# Altium schematic sheet P03_MPU2_Comm.SchDoc(P03_MPU2_Comm)
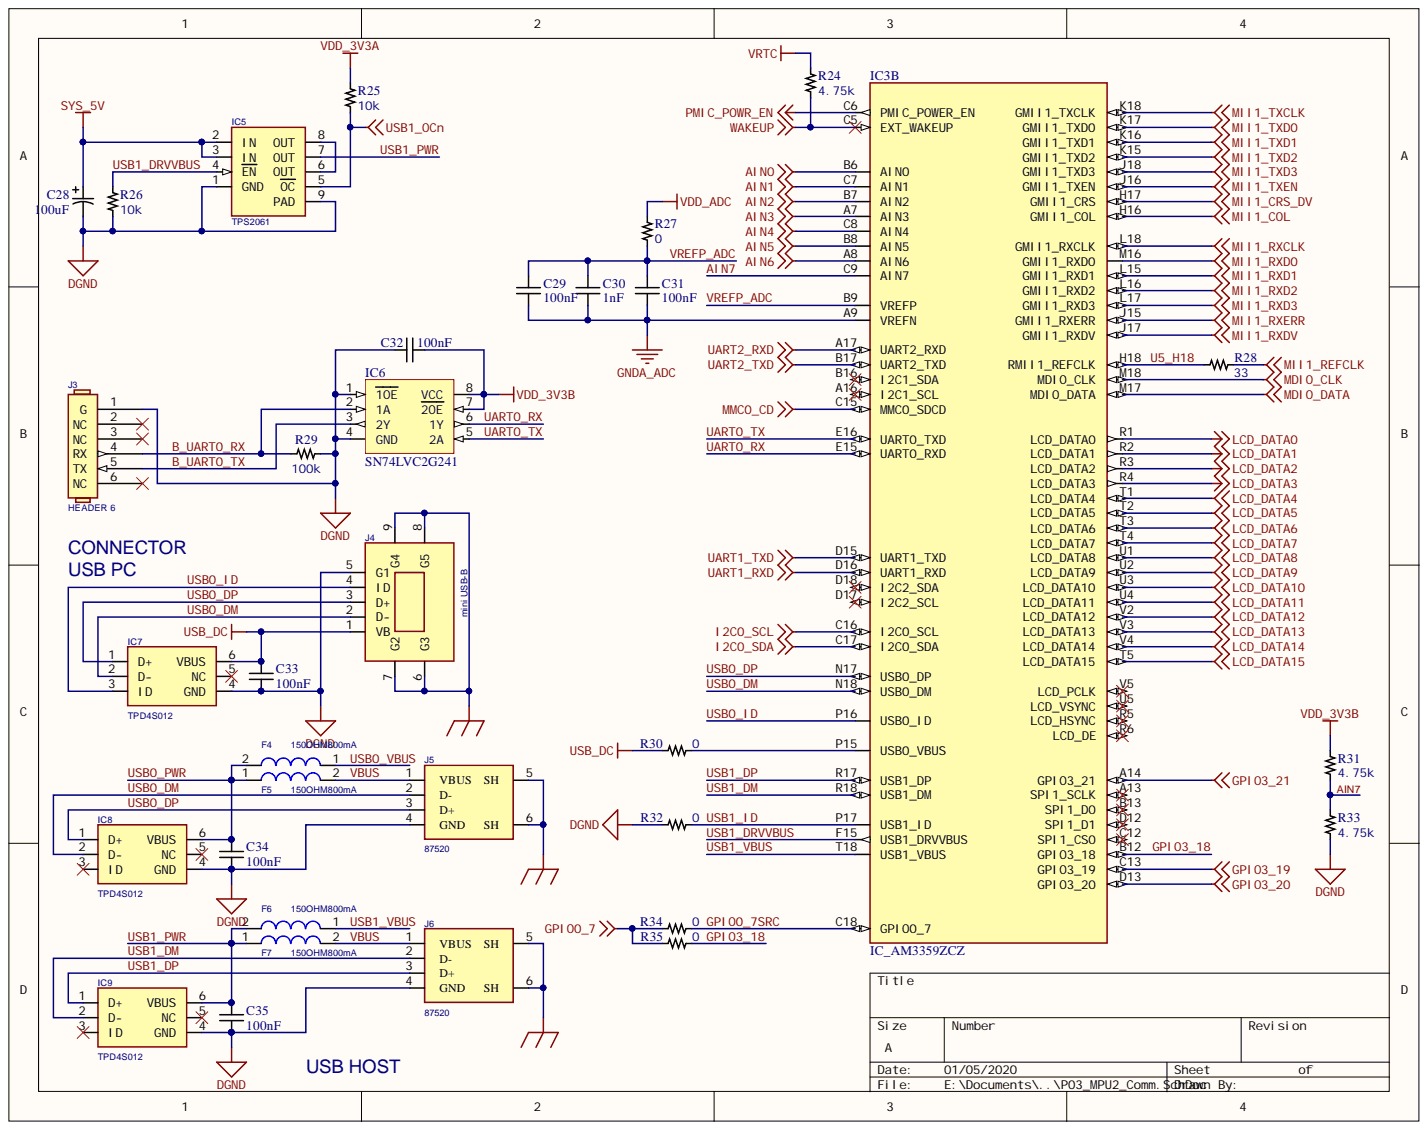
[diagram p0: P03_MPU2_Comm.SchDoc]

## Parts
C28  Capacitance: 100uF  [CAP6.6x6.6]  (hidden comment: EEE-0JA101SP)
C29  Capacitance: 100nF  [CAP0402]  (hidden comment: C0402C104K4RACTU)
C30  Capacitance: 1nF  [CAP0402]  (hidden comment: CC0402KRX7R9BB102)
C31  Capacitance: 100nF  [CAP0402]  (hidden comment: C0402C104K4RACTU)
C32  Capacitance: 100nF  [CAP0402]  (hidden comment: C0402C104K4RACTU)
C33  Capacitance: 100nF  [CAP0402]  (hidden comment: C0402C104K4RACTU)
C34  Capacitance: 100nF  [CAP0402]  (hidden comment: C0402C104K4RACTU)
C35  Capacitance: 100nF  [CAP0402]  (hidden comment: C0402C104K4RACTU)
F4  150OHM800mA  [FB0805]
F5  150OHM800mA  [FB0805]
F6  150OHM800mA  [FB0805]
F7  150OHM800mA  [FB0805]
IC3  IC_AM3359ZCZ  [BGA-324]  (part B)
IC5  TPS2061  [HVSSOP-8]
IC6  SN74LVC2G241  [VSSOP-8]
IC7  TPD4S012  [U_6_DRY]
IC8  TPD4S012  [U_6_DRY]
IC9  TPD4S012  [U_6_DRY]
J3  HEADER 6  [SIP6]
J4  mini USB-B  [USB5MINI_4SHIELDED_SMD-5]
J5  87520  [USB_A]
J6  87520  [USB_A]
R24  Resistance: 4.75k  [RES0402]  (hidden comment: RC0402FR-074K75L)
R25  Resistance: 10k  [RES0402]  (hidden comment: RC0402FR-0710KL)
R26  Resistance: 10k  [RES0402]  (hidden comment: RC0402FR-0710KL)
R27  RC0402JR-070RL  [RES0402]  (value not drawn)
R28  RC0402FR-0733RL  [RES0402]  (value not drawn)
R29  Resistance: 100k  [RES0402]  (hidden comment: RC0402FR-07100KL)
R30  RC0402JR-070RL  [RES0402]  (value not drawn)
R31  Resistance: 4.75k  [RES0402]  (hidden comment: RC0402FR-074K75L)
R32  RC0402JR-070RL  [RES0402]  (value not drawn)
R33  Resistance: 4.75k  [RES0402]  (hidden comment: RC0402FR-074K75L)
R34  RC0402JR-070RL  [RES0402]  (value not drawn)
R35  RC0402JR-070RL  [RES0402]  (value not drawn)

## Nets
AIN0: IC3.B6(AIN0)
AIN1: IC3.C7(AIN1)
AIN2: IC3.B7(AIN2)
AIN3: IC3.A7(AIN3)
AIN4: IC3.C8(AIN4)
AIN5: IC3.B8(AIN5)
AIN6: IC3.A8(AIN6)
DGND: C28.2 C32.1 C33.2 C34.2 C35.2 IC5.1(GND) IC5.9(PAD) IC6.1(1OE) IC6.4(GND) IC7.4(GND) IC8.4(GND) IC9.4(GND) J3.1(G) J4.5(G1) J5.4(GND) J6.4(GND) R26.2 R29.1 R32.1 R33.1
GND_EARTH: J4.6(G3) J4.7(G2) J4.8(G5) J4.9(G4) J5.5(SH) J5.6(SH) J6.5(SH) J6.6(SH)
GNDA_ADC: C29.2 C30.2 C31.2 IC3.A9(VREFN)
GPIO0_7: R34.2 R35.1
GPIO3_19: IC3.C13(GPIO3_19)
GPIO3_20: IC3.D13(GPIO3_20)
GPIO3_21: IC3.A14(GPIO3_21)
I2C0_SCL: IC3.C16(I2C0_SCL)
I2C0_SDA: IC3.C17(I2C0_SDA)
LCD_DATA0: IC3.R1(LCD_DATA0)
LCD_DATA1: IC3.R2(LCD_DATA1)
LCD_DATA2: IC3.R3(LCD_DATA2)
LCD_DATA3: IC3.R4(LCD_DATA3)
LCD_DATA4: IC3.T1(LCD_DATA4)
LCD_DATA5: IC3.T2(LCD_DATA5)
LCD_DATA6: IC3.T3(LCD_DATA6)
LCD_DATA7: IC3.T4(LCD_DATA7)
LCD_DATA8: IC3.U1(LCD_DATA8)
LCD_DATA9: IC3.U2(LCD_DATA9)
LCD_DATA10: IC3.U3(LCD_DATA10)
LCD_DATA11: IC3.U4(LCD_DATA11)
LCD_DATA12: IC3.V2(LCD_DATA12)
LCD_DATA13: IC3.V3(LCD_DATA13)
LCD_DATA14: IC3.V4(LCD_DATA14)
LCD_DATA15: IC3.T5(LCD_DATA15)
MDIO_CLK: IC3.M18(MDIO_CLK)
MDIO_DATA: IC3.M17(MDIO_DATA)
MII1_COL: IC3.H16(GMII1_COL)
MII1_CRS_DV: IC3.H17(GMII1_CRS)
MII1_REFCLK: R28.2
MII1_RXCLK: IC3.L18(GMII1_RXCLK)
MII1_RXD0: IC3.M16(GMII1_RXD0)
MII1_RXD1: IC3.L15(GMII1_RXD1)
MII1_RXD2: IC3.L16(GMII1_RXD2)
MII1_RXD3: IC3.L17(GMII1_RXD3)
MII1_RXDV: IC3.J17(GMII1_RXDV)
MII1_RXERR: IC3.J15(GMII1_RXERR)
MII1_TXCLK: IC3.K18(GMII1_TXCLK)
MII1_TXD0: IC3.K17(GMII1_TXD0)
MII1_TXD1: IC3.K16(GMII1_TXD1)
MII1_TXD2: IC3.K15(GMII1_TXD2)
MII1_TXD3: IC3.J18(GMII1_TXD3)
MII1_TXEN: IC3.J16(GMII1_TXEN)
MMC0_CD: IC3.C15(MMC0_SDCD)
PMIC_POWR_EN: IC3.C6(PMIC_POWER_EN)
SYS_5V: C28.1 IC5.2(IN) IC5.3(IN)
UART1_RXD: IC3.D16(UART1_RXD)
UART1_TXD: IC3.D15(UART1_TXD)
UART2_RXD: IC3.A17(UART2_RXD)
UART2_TXD: IC3.B17(UART2_TXD)
USB1_OCn: IC5.5(OC) R25.2
USB_DC: C33.1 IC7.6(VBUS) J4.1(VB) R30.1
VDD_3V3A: R25.1
VDD_3V3B: C32.2 IC6.7(2OE) IC6.8(VCC) R31.2
VDD_ADC: R27.2
VRTC: R24.2
WAKEUP: IC3.C5(EXT_WAKEUP) R24.1
AIN7: IC3.C9(AIN7) R31.1 R33.2
B_UART0_RX: IC6.2(1A) J3.4(RX) R29.2
B_UART0_TX: IC6.3(2Y) J3.5(TX)
GPIO0_7SRC: IC3.C18(GPIO0_7) R34.1
GPIO3_18: IC3.B12(GPIO3_18) R35.2
U5_H18: IC3.H18(RMII1_REFCLK) R28.1
UART0_RX: IC3.E15(UART0_RXD) IC6.6(1Y)
UART0_TX: IC3.E16(UART0_TXD) IC6.5(2A)
USB0_DM: IC3.N18(USB0_DM) IC7.2(D-) IC8.2(D-) J4.2(D-) J5.2(D-)
USB0_DP: IC3.N17(USB0_DP) IC7.1(D+) IC8.1(D+) J4.3(D+) J5.3(D+)
USB0_ID: IC3.P16(USB0_ID) IC7.3(ID) J4.4(ID)
USB0_PWR: C34.1 F4.2 F5.1 IC8.6(VBUS)
USB0_VBUS: F4.1
USB1_DM: IC3.R18(USB1_DM) IC9.2(D-) J6.2(D-)
USB1_DP: IC3.R17(USB1_DP) IC9.1(D+) J6.3(D+)
USB1_DRVVBUS: IC3.F15(USB1_DRVVBUS) IC5.4(EN) R26.1
USB1_ID: IC3.P17(USB1_ID) R32.2
USB1_PWR: C35.1 F6.2 F7.1 IC5.7(OUT) IC9.6(VBUS)
USB1_VBUS: F6.1 IC3.T18(USB1_VBUS)
VBUS: F5.2 F7.2 J5.1(VBUS) J6.1(VBUS)
VREFP_ADC: C29.1 C30.1 C31.1 IC3.B9(VREFP) R27.1
NetIC3_P15: IC3.P15(USB0_VBUS) R30.2
NetIC5_6: IC5.6(OUT) IC5.8(OUT)

Not connected: IC3 A13(SPI1_SCLK) A16(I2C1_SCL) B13(SPI1_D0) B16(I2C1_SDA) C12(SPI1_CS0) D12(SPI1_D1) D17(I2C2_SCL) D18(I2C2_SDA) R5(LCD_HSYNC) R6(LCD_DE) U5(LCD_VSYNC) V5(LCD_PCLK); IC7 5(NC); IC8 3(ID) 5(NC); IC9 3(ID) 5(NC); J3 2(NC) 3(NC) 6(NC)

## Library data of the parts
C28 = CAP_POL_100uF: POL CAP 100uF SMD; Manufacturer: Panasonic; Voltage Rating (DC): 6.3V; Tolerance: 20%; Ripple Current (AC): 71mA; Life (Hours): 2000Hour; Leakage Current: 3uA; Lead Length: 2.6mm; Dielectric Material: Aluminium; Width: 6.6mm; Voltage Rating: 6.3V; Voltage: 6.3V; Termination: SMD/SMT; Supplier Part Number 1: PCE3852CT-ND; Supplier 1: Digi-Key; Stock 1: 67789; RoHS: Yes; Ripple Current: 71mA; REACH SVHC: No SVHC; Radiation Hardening: No; Pricing 1: 1=0.35000, 10=0.24000, 100=0.14420, 500=0.10816 (USD); Packaging: Tape and Reel; Package Quantity: 1; Number of Pins: 2; Mount: Surface Mount,PCB; Min Operating Temperature: -40°C; Max Operating Temperature: 85°C; Manufacturer Part Number: EEE-0JA101SP; Length: 5.5mm; Lead Pitch: 2.2mm; Lead Free: Lead Free; Height - Seated (Max): 0.213inch; Height: 5.4mm; Features: General Purpose; Diameter: 6.3mm; Contact Plating: Tin; ComponentLink14URL: https://datasheet.ciiva.com/17353/aba0000ce2-17353295.pdf?src-supplier=Avnet+Europe; ComponentLink14Description: https://datasheet.ciiva.com/17353/aba0000ce2-17353295.pdf?src-supplier=Avnet+Europe; ComponentLink13URL: https://datasheet.ciiva.com/15165/getdatasheetpartid-73778-15165850.pdf?src-supplier=Verical; ComponentLink13Description: https://datasheet.ciiva.com/15165/getdatasheetpartid-73778-15165850.pdf?src-supplier=Verical; ComponentLink12URL: https://datasheet.ciiva.com/16539/aba0000ce2-16539929.pdf?src-supplier=Avnet+Asia; ComponentLink12Description: https://datasheet.ciiva.com/16539/aba0000ce2-16539929.pdf?src-supplier=Avnet+Asia; ComponentLink11URL: https://datasheet.ciiva.com/6134/aba0000ce2-6134361.pdf?src-supplier=Farnell; ComponentLink11Description: https://datasheet.ciiva.com/6134/aba0000ce2-6134361.pdf?src-supplier=Farnell; ComponentLink10URL: https://datasheet.ciiva.com/46376/aba0000ce2-46376375.pdf?src-supplier=Element14; ComponentLink10Description: https://datasheet.ciiva.com/46376/aba0000ce2-46376375.pdf?src-supplier=Element14; ComponentLink9URL: https://datasheet.ciiva.com/24070/aba0000ce2-40801-24070230.pdf?src-supplier=Mouser; ComponentLink9Description: https://datasheet.ciiva.com/24070/aba0000ce2-40801-24070230.pdf?src-supplier=Mouser; ComponentLink8URL: https://datasheet.ciiva.com/43485/aba0000ce2-43485605.pdf?src-supplier=Farnell; ComponentLink8Description: https://datasheet.ciiva.com/43485/aba0000ce2-43485605.pdf?src-supplier=Farnell; ComponentLink7URL: https://datasheet.ciiva.com/2983/aba0000ce2-2983902.pdf?src-supplier=Avnet; ComponentLink7Description: https://datasheet.ciiva.com/2983/aba0000ce2-2983902.pdf?src-supplier=Avnet; ComponentLink6URL: https://datasheet.ciiva.com/14595/70255710-14595757.pdf?src-supplier=Allied+Electronics; ComponentLink6Description: https://datasheet.ciiva.com/14595/70255710-14595757.pdf?src-supplier=Allied+Electronics; ComponentLink5URL: https://datasheet.ciiva.com/46387/aba0000ce2-46387964.pdf?src-supplier=Element14; ComponentLink5Description: https://datasheet.ciiva.com/46387/aba0000ce2-46387964.pdf?src-supplier=Element14; ComponentLink4URL: https://datasheet.ciiva.com/16397/aba0000ce2-16397066.pdf?src-supplier=Future+Electronics; ComponentLink4Description: https://datasheet.ciiva.com/16397/aba0000ce2-16397066.pdf?src-supplier=Future+Electronics; ComponentLink3URL: https://datasheet.ciiva.com/30226/11aba0000ce2-30226184.pdf?src-supplier=Onlinecomponents.com; ComponentLink3Description: https://datasheet.ciiva.com/30226/11aba0000ce2-30226184.pdf?src-supplier=Onlinecomponents.com; ComponentLink2URL: https://datasheet.ciiva.com/14610/70255710-14610022.pdf?src-supplier=Allied+Electronics; ComponentLink2Description: https://datasheet.ciiva.com/14610/70255710-14610022.pdf?src-supplier=Allied+Electronics; ComponentLink1URL: https://datasheet.ciiva.com/11779/0900766b8078636e-11779744.pdf?src-supplier=RSComponents; ComponentLink1Description: https://datasheet.ciiva.com/11779/0900766b8078636e-11779744.pdf?src-supplier=RSComponents; Component Kind: Standard; Case/Package: Radial; Library Name: Orient.SchLib
C29 C31-C35 = CAP_100nF: MLCC 100nF 0402 SMD; Manufacturer: KEMET; Voltage Rating (DC): 16V; Tolerance: 10%; Dissipation Factor: 3.5%; Dielectric: X7R; Width: 0.5mm; Voltage Rating: 16V; Termination: SMD/SMT; Supplier Part Number 1: 399-3521-1-ND; Supplier 1: Digi-Key; Stock 1: 6512243; RoHS: Yes; Radiation Hardening: No; Pricing 1: 1=0.10000, 10=0.04200, 100=0.01860, 500=0.01328, 1000=0.01044, 2500=0.00949, 5000=0.00873 (USD); Packaging: Tape and Reel; Package Quantity: 10000; Mount: Surface Mount; Min Operating Temperature: -55°C; Max Operating Temperature: 125°C; Manufacturer Part Number: C0402C104K4RACTU; Length: 1mm; Height: 0.5mm; Depth: 0.5mm; Contact Plating: Tin,Matte; ComponentLink2URL: https://datasheet.ciiva.com/18192/getdatasheetpartid-385384-18192412.pdf?src-supplier=Verical; ComponentLink2Description: Datasheet; Component Kind: Standard; Case Code (Metric): 1005; Case Code (Imperial): 0402; Case/Package: 0402; Library Name: Orient.SchLib
C30 = CAP_1nF: MLCC 1nF 0402 SMD; Manufacturer: Yageo; Voltage Rating: 50V; Tolerance: 10%; Insulation Resistance: 100GR; Dielectric Material: Ceramic; Width: 0.02inch; Thickness: 0.022inch; Supplier 1: Digi-Key; Stock 1: 3539064; RoHS: Yes; Pricing 1: 1=0.10000, 10=0.02100, 100=0.00920, 500=0.00654, 1000=0.00514, 2500=0.00468, 5000=0.00430 (USD); Packaging: Tape and Reel; Mount: Surface Mount; Min Operating Temperature: -55°C; Max Operating Temperature: 125°C; Manufacturer Part Number: CC0402KRX7R9BB102; Length: 0.039inch; Lead Free: Lead Free; Halogen Free: Halogen Free; ComponentLink9URL: https://datasheet.ciiva.com/22749/1489800-22749213.pdf?src-supplier=Element14; ComponentLink9Description: https://datasheet.ciiva.com/22749/1489800-22749213.pdf?src-supplier=Element14; ComponentLink8URL: https://datasheet.ciiva.com/7978/1489800-7978092.pdf?src-supplier=Element14; ComponentLink8Description: https://datasheet.ciiva.com/7978/1489800-7978092.pdf?src-supplier=Element14; ComponentLink7URL: https://datasheet.ciiva.com/15189/getdatasheetpartid-403474-15189131.pdf?src-supplier=Verical; ComponentLink7Description: https://datasheet.ciiva.com/15189/getdatasheetpartid-403474-15189131.pdf?src-supplier=Verical; ComponentLink6URL: https://datasheet.ciiva.com/16625/upy-gphc-x7r-6-3v-to-50v-8-16625512.pdf?src-supplier=Avnet+Europe; ComponentLink6Description: https://datasheet.ciiva.com/16625/upy-gphc-x7r-6-3v-to-50v-8-16625512.pdf?src-supplier=Avnet+Europe; ComponentLink5URL: https://datasheet.ciiva.com/13389/upy-gp-np0-16v-to-50v-8-13389974.pdf?src-supplier=Mouser; ComponentLink5Description: https://datasheet.ciiva.com/13389/upy-gp-np0-16v-to-50v-8-13389974.pdf?src-supplier=Mouser; ComponentLink4URL: https://datasheet.ciiva.com/143/mlccorderingcode-143958.pdf?src-supplier=Digi-Key; ComponentLink4Description: https://datasheet.ciiva.com/143/mlccorderingcode-143958.pdf?src-supplier=Digi-Key; ComponentLink3URL: https://datasheet.ciiva.com/143/mlccorderingcode-143957.pdf?src-supplier=Digi-Key; ComponentLink3Description: https://datasheet.ciiva.com/143/mlccorderingcode-143957.pdf?src-supplier=Digi-Key; ComponentLink2URL: https://datasheet.ciiva.com/143/mlccorderingcode-143956.pdf?src-supplier=Digi-Key; ComponentLink2Description: https://datasheet.ciiva.com/143/mlccorderingcode-143956.pdf?src-supplier=Digi-Key; ComponentLink1URL: https://datasheet.ciiva.com/11786/0900766b8079c870-11786157.pdf?src-supplier=RSComponents; ComponentLink1Description: https://datasheet.ciiva.com/11786/0900766b8079c870-11786157.pdf?src-supplier=RSComponents; Component Kind: Standard; Case Code (Metric): 1005; Case Code (Imperial): 0402; Case/Package: 0402; Library Name: Orient.SchLib
F4-F7 = FERR: FERRITE 800MA 150 OHM 0805 SMD; TIIntPartnumber: 1L0010; PartName: FerriteBead150ohm800mA; MfrNum: LI0805H151R-10; Mfr: Steward; Manufacturer: Laird-Signal Integrity Products; Vendor: Digikey; Impedance @ Frequency: 150 Ohm @ 100MHz; Filter Type: Differential Mode - Single; DC Resistance (DCR): 150 mOhm Max; Supplier Part Number 1: 240-2384-6-ND; Supplier 1: Digi-Key; Stock 1: 3694; Size / Dimension: 0.079" L x 0.049" W (2.00mm x 1.25mm); RoHS: RoHS Compliant; Pricing 1: 1=0.1, 10=0.07, 25=0.064, 50=0.0574, 100=0.0421, 250=0.03064, 500=0.02808, 1000=0.02424 (USD); Packaging: Digi-Reel®; Package / Case: 0805 (2012 Metric); Mounting Type: Surface Mount; Manufacturer Part Number: LI0805H151R-10; Height (Max): 0.043" (1.10mm); Current Rating: 800mA; ComponentLink1URL: http://www.lairdtech.com/WorkArea/linkit.aspx?LinkIdentifier=id&ItemID=4912; ComponentLink1Description: http://www.lairdtech.com/WorkArea/linkit.aspx?LinkIdentifier=id&ItemID=4912; Component Kind: Standard; Category: Filters; Library Name: Orient.SchLib
IC3 = IC_AM335X_ZCE_1: SubArctic Cortex A8 Processor 18x18; Manufacturer Part Number: XAM3359ZCZ; Manufacturer: Texas Instruments; Voltage: 1.06 V ~ 1.15 V; Processor Type: ARM Microprocessor; Supplier Part Number 1: 296-35073-ND; Supplier Device Package: 324-NFBGA(15x15); Supplier 1: Digi-Key; Stock 1: 16; Speed: -; RoHS: RoHS Compliant; Pricing 1: 1=33.5, 10=30.988, 100=26.465, 250=24.28752, 500=23.115, 1000=21.775, 2500=21.105, 5000=20.6025, 10000=20.1 (USD); Packaging: Tray; Package / Case: 324-LFBGA; Mounting Type: Surface Mount; Features: -; ComponentLink1URL: http://www.ti.com/lit/ds/symlink/am3354.pdf; ComponentLink1Description: http://www.ti.com/lit/ds/symlink/am3354.pdf; Component Kind: Standard; Category: Integrated Circuits (ICs); Library Name: Orient.SchLib
IC5 = TPS2061: IC 1.5A PWR DIST SWITCH 8-SOIC; Manufacturer Part Number: TPS2051BDGNR; Manufacturer: Texas Instruments; Voltage - Input: 2.7 V ~ 5.5 V; Rds (On): 135 mOhm; Number of Outputs: 1; Internal Switch(s): Yes; Current Limit: 1A; Type: High Side Switch; Supplier Part Number 1: 296-17313-6-ND; Supplier Device Package: 8-MSOP-PowerPad; Supplier 1: Digi-Key; Stock 1: 7065; RoHS: RoHS Compliant; Pricing 1: 1=1.82, 10=1.642, 25=1.4708, 100=1.3224, 250=1.1742, 500=1.026, 1000=0.8493 (USD); Packaging: Digi-Reel®; Package / Case: 8-TSSOP, 8-MSOP (0.118", 3.00mm Width) Exposed Pad; Operating Temperature: -40°C ~ 85°C; Mounting Type: Surface Mount; ComponentLink1URL: http://www.ti.com/lit/ds/symlink/tps2061.pdf; ComponentLink1Description: Datasheet; Component Kind: Standard; Category: Integrated Circuits (ICs); Library Name: Orient.SchLib
IC6 = SN74LVC2G241: IC BUFF/DVR TRI-ST DL 8VSSOP; Manufacturer Part Number: SN74LVC2G241DCUR; Manufacturer: Texas Instruments; Voltage - Supply: 1.65 V ~ 5.5 V; Number of Elements: 2; Number of Bits per Element: 1; Logic Type: Buffer/Line Driver, Non-Inverting; Current - Output High, Low: 32mA, 32mA; Supplier Part Number 1: 296-11936-6-ND; Supplier Device Package: US8; Supplier 1: Digi-Key; Stock 1: 28602; RoHS: RoHS Compliant; Pricing 1: 1=0.49, 10=0.428, 25=0.3756 (USD); Packaging: Digi-Reel®; Package / Case: 8-VFSOP (0.091", 2.30mm Width); Operating Temperature: -40°C ~ 85°C; Mounting Type: Surface Mount; ComponentLink1URL: http://www.ti.com/lit/ds/symlink/sn74lvc2g241.pdf; ComponentLink1Description: http://www.ti.com/lit/ds/symlink/sn74lvc2g241.pdf; Component Kind: Standard; Category: Integrated Circuits (ICs); Library Name: Orient.SchLib
IC7-IC9 = PROT_TPD4S012: ESD 4channel USB Interface; TIIntPartnumber: 1A0233; MfrNum: TPD4S012DRY; Mfr: TI; Manufacturer Part Number: TPD4S012DRYR; Manufacturer: Texas Instruments; Vendor: Digikey; Power (Watts): 60W; Number of Circuits: 4; Cost100: 0.76; Cost10K: 0.23; Voltage - Working: -; Voltage - Clamping: -; Technology: Mixed Technology; Supplier Part Number 1: 296-24244-6-ND; Supplier Device Package: 6-SON (1.45x1); Supplier 1: Digi-Key; Stock 1: 7978; RoHS: RoHS Compliant; Pricing 1: 1=0.78, 10=0.682, 25=0.6028, 100=0.2552, 250=0.25044, 500=0.2457, 750=0.24097 (USD); PartName: TPD4S012; Packaging: Digi-Reel®; Package / Case: 6-UFDFN; ComponentLink1URL: http://www.ti.com/lit/ds/symlink/tpd4s012.pdf; ComponentLink1Description: http://www.ti.com/lit/ds/symlink/tpd4s012.pdf; Component Kind: Standard; Category: Circuit Protection; Applications: USB; Library Name: Orient.SchLib
J3 = HDR_6x1: CONN HEADER 6POS, VERTICAL,  .100 STR TIN; Manufacturer Part Number: FTR-110-03-G-D-06; Manufacturer: Samtec Inc; Row Spacing: 0.100" (2.54mm); Pitch: 0.050" (1.27mm); Number of Positions Loaded: 19; Number of Positions: 20; Connector Type: Unshrouded; Termination: Solder; Supplier Part Number 1: FTR-110-03-G-D-06-ND; Supplier 1: Digi-Key; Stock 1: 0; RoHS: RoHS Compliant; Pricing 1: 1=4.47 (USD); Packaging: Tube; Number of Rows: 2; Mounting Type: Surface Mount; Molding Height Above Board: 0.100" (2.54mm); Height Stacking (Mating): -; Features: -; Contact Mating Length: 0.125" (3.18mm); Contact Finish Thickness: 10µin (0.25µm); Contact Finish: Gold; ComponentLink1URL: http://www.samtec.com/documents/webfiles/cpdf/FTR-1XX-XX-XX-D-X-XX-MKT.pdf; ComponentLink1Description: http://www.samtec.com/documents/webfiles/cpdf/FTR-1XX-XX-XX-D-X-XX-MKT.pdf; Component Kind: Standard; Color: Black; Category: Connectors, Interconnects; Library Name: Orient.SchLib
J4 = MINI-USB-AB-SOCKET: CONN RCPT USB MINI B R/A SMD; Manufacturer Part Number: 0565790576; Manufacturer: KYCON; Specifications: USB 2.0 OTG; Order# 2: C-440247; Order#: KMAB-SMT-5S-S-30TR; Number of Contacts: 5; Gender: Receptacle; Connector Type: USB - mini AB; ATLStockNo1: 4500.0223; Supplier Part Number 1: WM17122DKR-ND; Supplier 1: Digi-Key; Stock 1: 12426; RoHS: RoHS Compliant; Pricing 1: 1=2.92, 10=2.649, 25=2.4872, 50=2.3792, 100=2.271, 250=2.05468 (USD); Packaging: Digi-Reel®; Mounting Type: Surface Mount, Right Angle, Horizontal; Manufacturer 2: AMP; Ingress Protection: -; Features: Shielded; Distributor 2: Tyco; Distributor: Deltron; ComponentLink1URL: http://www.molex.com/pdm_docs/sd/565790576_sd.pdf; ComponentLink1Description: http://www.molex.com/pdm_docs/sd/565790576_sd.pdf; Component Kind: Standard; Category: Connectors, Interconnects; Library Name: Orient.SchLib
J5 J6 = CONN_USBA: CONN RCPT USB TYPE A R/A PCB; Manufacturer Part Number: 87520-0010BLF; Manufacturer: FCI; TN: 224-0234-000; Specifications: USB 2.0; Number of Contacts: 4; Gender: Receptacle; Connector Type: USB - A; CLASS: IO; Supplier Part Number 1: 609-1045-ND; Supplier 1: Digi-Key; Stock 1: 4363; RoHS: RoHS Compliant; Pricing 1: 1=0.78, 10=0.734, 25=0.6604, 50=0.587, 100=0.5626, 250=0.51368, 500=0.4892, 1000=0.40359, 2500=0.3669 (USD); Packaging: Tube; Mounting Type: Through Hole, Right Angle, Horizontal; Ingress Protection: -; Features: Board Lock; ComponentLink1URL: http://portal.fciconnect.com/Comergent//fci/drawing/87520.pdf; ComponentLink1Description: http://portal.fciconnect.com/Comergent//fci/drawing/87520.pdf; Component Kind: Standard; Category: Connectors, Interconnects; Library Name: Orient.SchLib
R24 R31 R33 = RES_4.75k: Resistor 4.75kOhm 0402 SMD; Manufacturer: Yageo; Working Voltage: 50V; Tolerance: 1%; Temperature Coefficient: 100ppm/°C; Power Rating: 62.5mW; Max Power Dissipation: 63mW; Width: 0.5mm; Voltage Rating (DC): 50V; Voltage Rating: 50V; Termination: SMD/SMT; Supplier 1: Digi-Key; Stock 1: 9368381; RoHS: Yes; REACH SVHC: No SVHC; Pricing 1: 1=0.10000, 10=0.01600, 100=0.00640, 1000=0.00289, 2500=0.00251, 5000=0.00207 (USD); Packaging: Tape and Reel; Package Quantity: 1; Number of Terminations: 2; Min Operating Temperature: -55°C; Military Standard: Not; Max Operating Temperature: 155°C; Manufacturer Part Number: RC0402FR-074K75L; Length: 1mm; Lead Free: Lead Free; Height: 0.016inch; Features: Moisture Resistant; Depth: 0.5mm; Composition: Thick Film; ComponentLink7URL: https://datasheet.ciiva.com/16744/pyu-rc0402-51-rohs-l-6-r-16744524.pdf?src-supplier=Future+Electronics; ComponentLink7Description: https://datasheet.ciiva.com/16744/pyu-rc0402-51-rohs-l-6-r-16744524.pdf?src-supplier=Future+Electronics; ComponentLink6URL: https://datasheet.ciiva.com/2553/pyu-r-int-thick-7-2553226.pdf?src-supplier=Digi-Key; ComponentLink6Description: https://datasheet.ciiva.com/2553/pyu-r-int-thick-7-2553226.pdf?src-supplier=Digi-Key; ComponentLink5URL: https://datasheet.ciiva.com/2553/pyu-r-int-thick-7-2553225.pdf?src-supplier=Digi-Key; ComponentLink5Description: https://datasheet.ciiva.com/2553/pyu-r-int-thick-7-2553225.pdf?src-supplier=Digi-Key; ComponentLink4URL: https://datasheet.ciiva.com/7983/1018594-7983544.pdf?src-supplier=Element14; ComponentLink4Description: https://datasheet.ciiva.com/7983/1018594-7983544.pdf?src-supplier=Element14; ComponentLink3URL: https://datasheet.ciiva.com/2553/pyu-r-int-thick-7-2553224.pdf?src-supplier=Digi-Key; ComponentLink3Description: https://datasheet.ciiva.com/2553/pyu-r-int-thick-7-2553224.pdf?src-supplier=Digi-Key; ComponentLink2URL: https://datasheet.ciiva.com/15189/getdatasheetpartid-326465-15189478.pdf?src-supplier=Verical; ComponentLink2Description: https://datasheet.ciiva.com/15189/getdatasheetpartid-326465-15189478.pdf?src-supplier=Verical; ComponentLink1URL: https://datasheet.ciiva.com/11808/0900766b80c66297-11808814.pdf?src-supplier=RSComponents; ComponentLink1Description: https://datasheet.ciiva.com/11808/0900766b80c66297-11808814.pdf?src-supplier=RSComponents; Component Kind: Standard; Case Code (Metric): 1005; Case Code (Imperial): 0402; Case/Package: 0402; Library Name: Orient.SchLib
R25 R26 = RES_10k: Resistor 10kOhm 0402 SMD; Manufacturer: Yageo; Working Voltage: 50V; Tolerance: 1%; Temperature Coefficient: 100ppm/°C; Power Rating: 62.5mW; Width: 0.5mm; Voltage Rating (DC): 50V; Voltage Rating: 50V; Termination: SMD/SMT; Supplier 1: Digi-Key; Stock 1: 72891652; RoHS: Yes; REACH SVHC: No SVHC; Pricing 1: 1=0.10000, 10=0.01500, 100=0.00600, 1000=0.00270, 2500=0.00235, 5000=0.00194 (USD); Packaging: Tape and Reel; Number of Terminations: 2; Number of Pins: 2; Min Operating Temperature: -55°C; Military Standard: Not; Max Operating Temperature: 155°C; Manufacturer Part Number: RC0402FR-0710KL; Length: 1mm; Lead Pitch: 0.2mm; Lead Free: Lead Free; Height: 0.32mm; Depth: 0.5mm; Composition: Thick Film; ComponentLink7URL: https://datasheet.ciiva.com/12667/pyu-rc0402-51-rohs-l-6-r-12667067.pdf?src-supplier=Mouser; ComponentLink7Description: https://datasheet.ciiva.com/12667/pyu-rc0402-51-rohs-l-6-r-12667067.pdf?src-supplier=Mouser; ComponentLink6URL: https://datasheet.ciiva.com/22747/1018594-22747512.pdf?src-supplier=Element14; ComponentLink6Description: https://datasheet.ciiva.com/22747/1018594-22747512.pdf?src-supplier=Element14; ComponentLink5URL: https://datasheet.ciiva.com/7849/1784430-7849815.pdf?src-supplier=Element14; ComponentLink5Description: https://datasheet.ciiva.com/7849/1784430-7849815.pdf?src-supplier=Element14; ComponentLink4URL: https://datasheet.ciiva.com/7849/1686128-7849814.pdf?src-supplier=Element14; ComponentLink4Description: https://datasheet.ciiva.com/7849/1686128-7849814.pdf?src-supplier=Element14; ComponentLink3URL: https://datasheet.ciiva.com/7849/1784430-7849813.pdf?src-supplier=Element14; ComponentLink3Description: https://datasheet.ciiva.com/7849/1784430-7849813.pdf?src-supplier=Element14; ComponentLink2URL: https://datasheet.ciiva.com/7983/1018594-7983486.pdf?src-supplier=Element14; ComponentLink2Description: https://datasheet.ciiva.com/7983/1018594-7983486.pdf?src-supplier=Element14; ComponentLink1URL: https://datasheet.ciiva.com/7660/38568-7660787.pdf?src-supplier=Element14; ComponentLink1Description: https://datasheet.ciiva.com/7660/38568-7660787.pdf?src-supplier=Element14; Component Kind: Standard; Case Code (Metric): 1005; Case Code (Imperial): 0402; Case/Package: 0402; Library Name: Orient.SchLib
R27 R30 R32 R34 R35 = RES_0: Resistor 0Ohm 0402 SMD; Manufacturer: Yageo; Voltage Rating: 50V; Tolerance: 5%; Temperature Coefficient: 200ppm/°C; Resistance: 0; Power Rating: 62.5mW; Max Power Dissipation: 63mW; Width: 0.02inch; Termination: SMD/SMT; Supplier Part Number 1: 311-0.0JRCT-ND; Supplier 1: Digi-Key; Stock 1: 68160806; RoHS: Yes; REACH SVHC: Unknown; Radiation Hardening: No; Pricing 1: 1=0.10000, 10=0.01300, 25=0.00920, 50=0.00700, 100=0.00510, 250=0.00392, 500=0.00312, 1000=0.00230, 5000=0.00165 (USD); Packaging: Tape and Reel; Package Quantity: 1; Number of Terminations: 2; Min Operating Temperature: -55°C; Military Standard: Not; Max Operating Temperature: 155°C; Manufacturer Part Number: RC0402JR-070RL; Length: 1mm; Lead Free: Lead Free; Height: 0.016inch; Features: Moisture Resistant; Depth: 0.5mm; Contact Plating: Tin,Matte; Composition: Thick Film; ComponentLink2URL: https://www.yageo.com/upload/media/product/productsearch/datasheet/rchip/PYu-RC_Group_51_RoHS_L_10.pdf; ComponentLink2Description: Datasheet; ComponentLink1URL: https://www.digikey.com/product-detail/en/yageo/RC0402JR-070RL/311-0.0JRCT-ND/729353; ComponentLink1Description: Digikey; Component Kind: Standard; Case Code (Metric): 1005; Case Code (Imperial): 0402; Case/Package: 0402; Library Name: Orient.SchLib
R28 = RES_33: Resistor 33Ohm 0402 SMD; Manufacturer: Yageo; Voltage Rating (DC): 50V; Tolerance: 1%; Temperature Coefficient: 100ppm/°C; Resistance: 33; Power Rating: 62.5mW; Width: 0.5mm; Voltage Rating: 50V; Termination: SMD/SMT; Supplier 1: Digi-Key; Stock 1: 5631148; RoHS: Yes; REACH SVHC: Unknown; Pricing 1: 1=0.10000, 10=0.01600, 100=0.00640, 1000=0.00289, 2500=0.00251, 5000=0.00207 (USD); Packaging: Tape and Reel; Package Quantity: 10000; Number of Pins: 2; Min Operating Temperature: -55°C; Military Standard: Not; Max Operating Temperature: 155°C; Manufacturer Part Number: RC0402FR-0733RL; Length: 1mm; Lead Free: Lead Free; Height: 0.35mm; Depth: 0.5mm; Composition: Thick Film; ComponentLink6URL: https://datasheet.ciiva.com/17070/pyu-rc0402-51-rohs-l-6-r-17070335.pdf?src-supplier=Future+Electronics; ComponentLink6Description: https://datasheet.ciiva.com/17070/pyu-rc0402-51-rohs-l-6-r-17070335.pdf?src-supplier=Future+Electronics; ComponentLink5URL: https://datasheet.ciiva.com/7849/1018594-7849967.pdf?src-supplier=Element14; ComponentLink5Description: https://datasheet.ciiva.com/7849/1018594-7849967.pdf?src-supplier=Element14; ComponentLink4URL: https://datasheet.ciiva.com/7849/1018594-7849966.pdf?src-supplier=Element14; ComponentLink4Description: https://datasheet.ciiva.com/7849/1018594-7849966.pdf?src-supplier=Element14; ComponentLink3URL: https://datasheet.ciiva.com/24070/pyu-rc0402-51-rohs-l-6-r-8721-24070077.pdf?src-supplier=Mouser; ComponentLink3Description: https://datasheet.ciiva.com/24070/pyu-rc0402-51-rohs-l-6-r-8721-24070077.pdf?src-supplier=Mouser; ComponentLink2URL: https://datasheet.ciiva.com/15189/getdatasheetpartid-329253-15189431.pdf?src-supplier=Verical; ComponentLink2Description: https://datasheet.ciiva.com/15189/getdatasheetpartid-329253-15189431.pdf?src-supplier=Verical; ComponentLink1URL: https://datasheet.ciiva.com/11819/0900766b80c66297-11819487.pdf?src-supplier=RSComponents; ComponentLink1Description: https://datasheet.ciiva.com/11819/0900766b80c66297-11819487.pdf?src-supplier=RSComponents; Component Kind: Standard; Case Code (Metric): 1005; Case Code (Imperial): 0402; Case/Package: 0402; Library Name: Orient.SchLib
R29 = RES_100k: Resistor 100kOhm 0402 SMD; Manufacturer: Yageo; Working Voltage: 50V; Tolerance: 1%; Temperature Coefficient: 100ppm/°C; Power Rating: 63mW; Width: 0.02inch; Voltage Rating: 50V; Termination: SMD/SMT; Supplier Part Number 2: 311-100KLRCT-ND; Supplier Part Number 1: 603-RC0402FR-07100KL; Supplier 2: Digi-Key; Supplier 1: Mouser; RoHS: Yes; REACH SVHC: No SVHC; Packaging: Tape and Reel; Package Quantity: 1; Number of Terminations: 2; Min Operating Temperature: -55°C; Military Standard: Not; Max Power Dissipation: 63mW; Max Operating Temperature: 155°C; Manufacturer Part Number: RC0402FR-07100KL; Length: 0.039inch; Lead Free: Lead Free; Height: 0.016inch; Features: Moisture Resistant; Depth: 0.5mm; Composition: Thick Film; ComponentLink2URL: https://www.yageo.com/upload/media/product/productsearch/datasheet/rchip/PYu-RC_Group_51_RoHS_L_10.pdf; ComponentLink2Description: Datasheet; Component Kind: Standard; Case Code (Metric): 1005; Case Code (Imperial): 0402; Case/Package: 0402; Library Name: Orient.SchLib
